# Altium schematic sheet PixelClockController.SchDoc
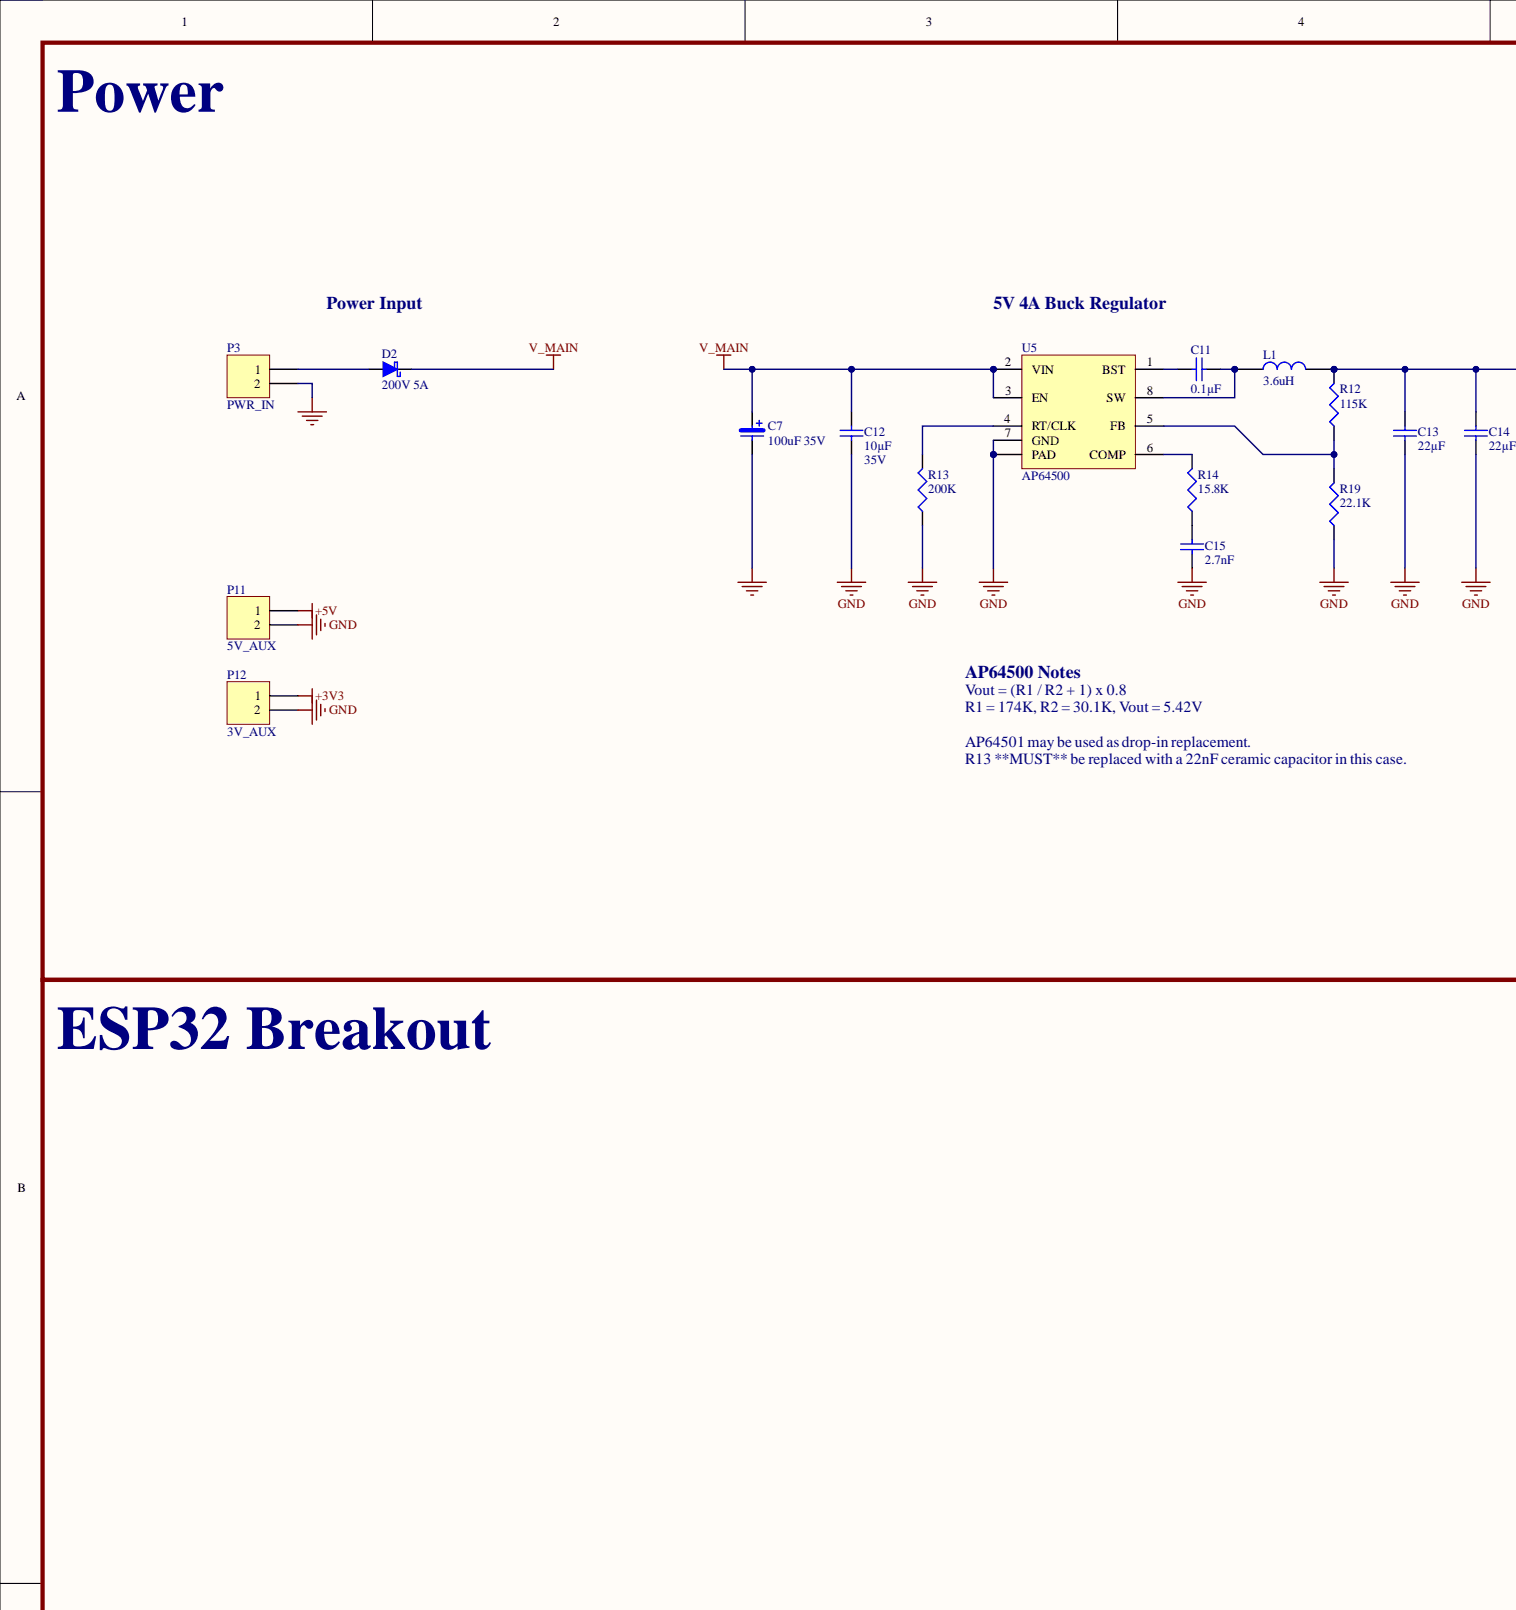
[diagram p0: PixelClockController.SchDoc, top left]
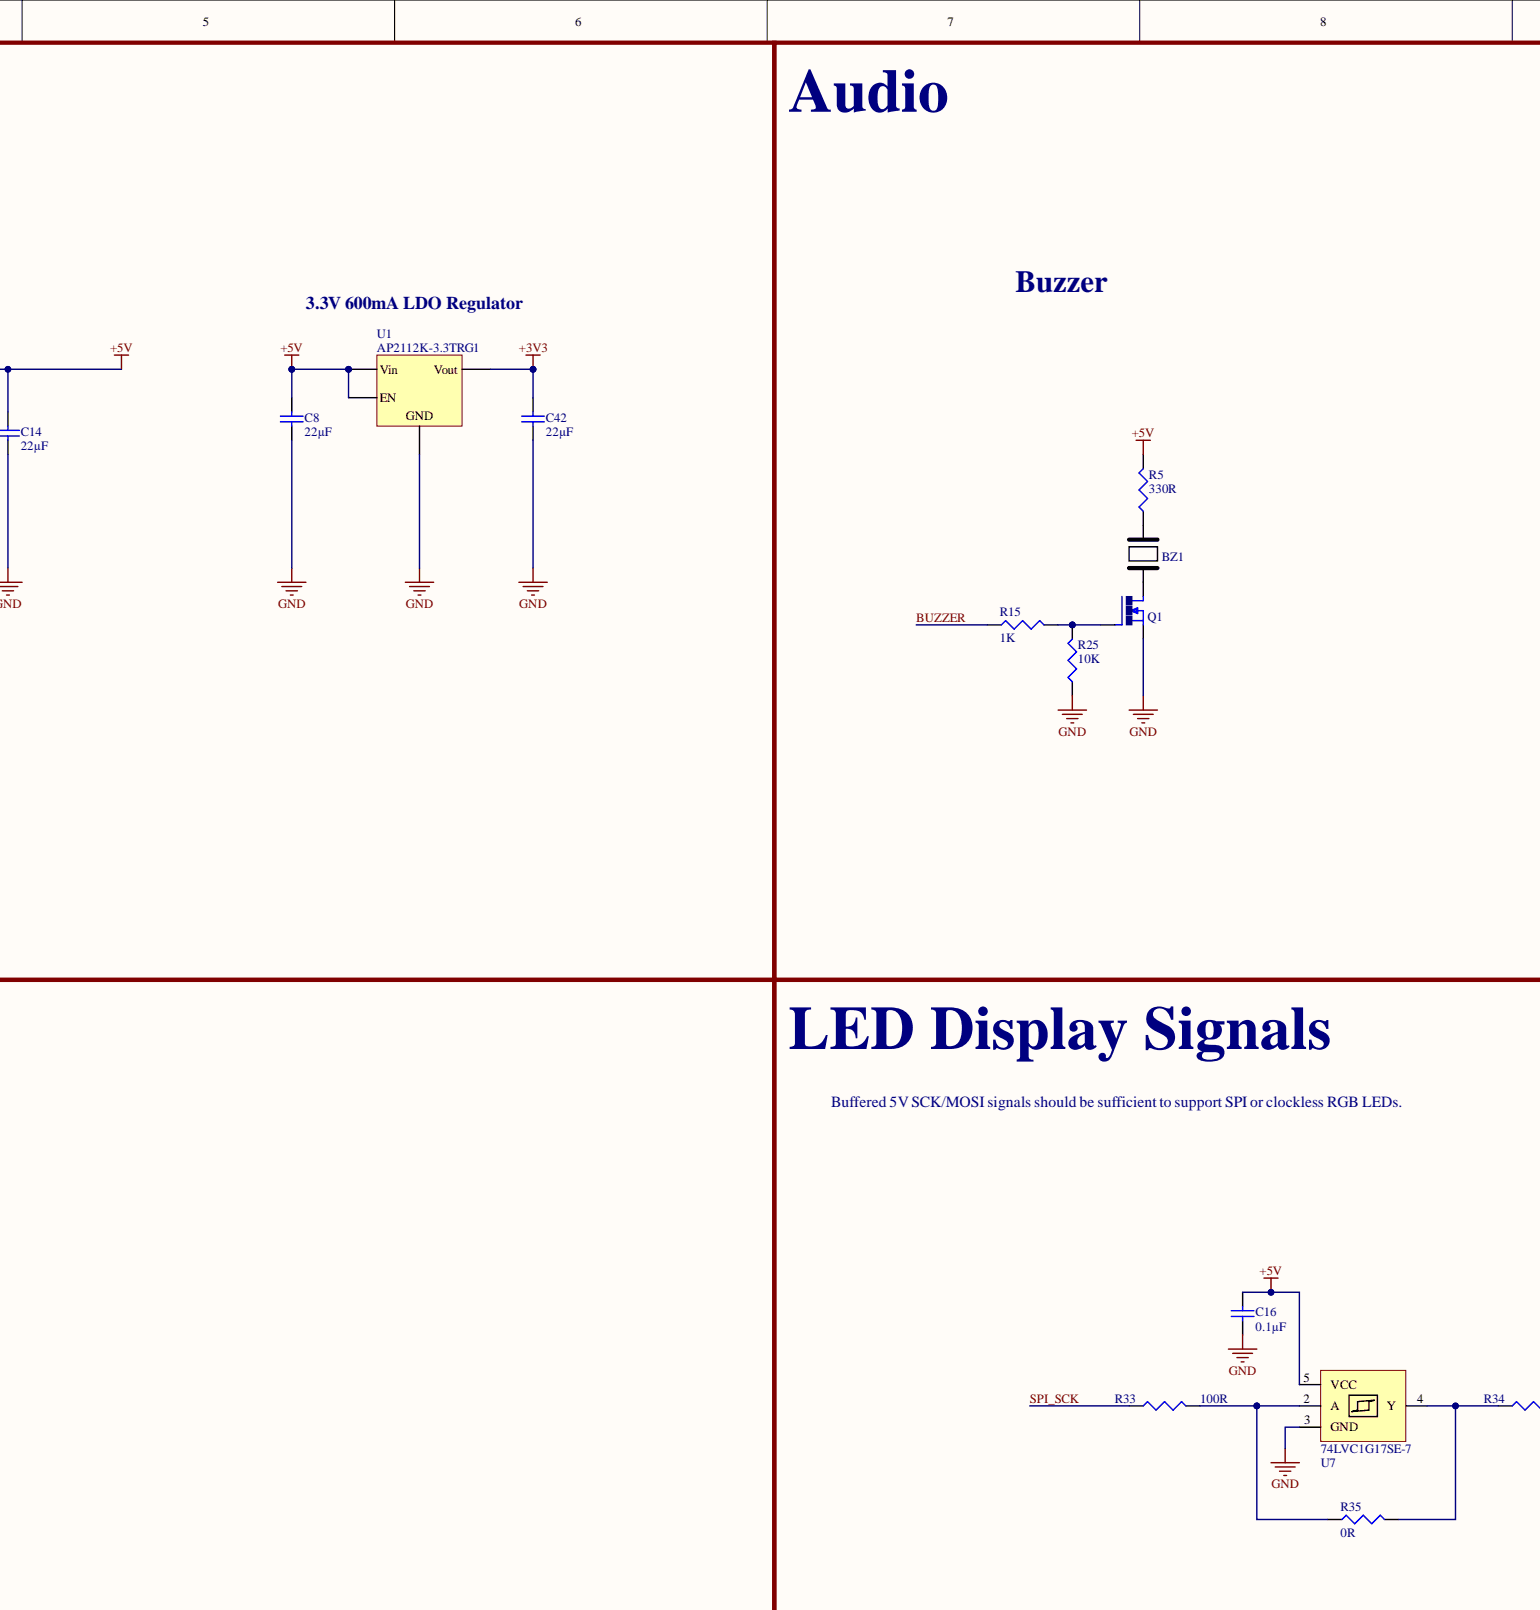
[diagram p1: PixelClockController.SchDoc, top centre]
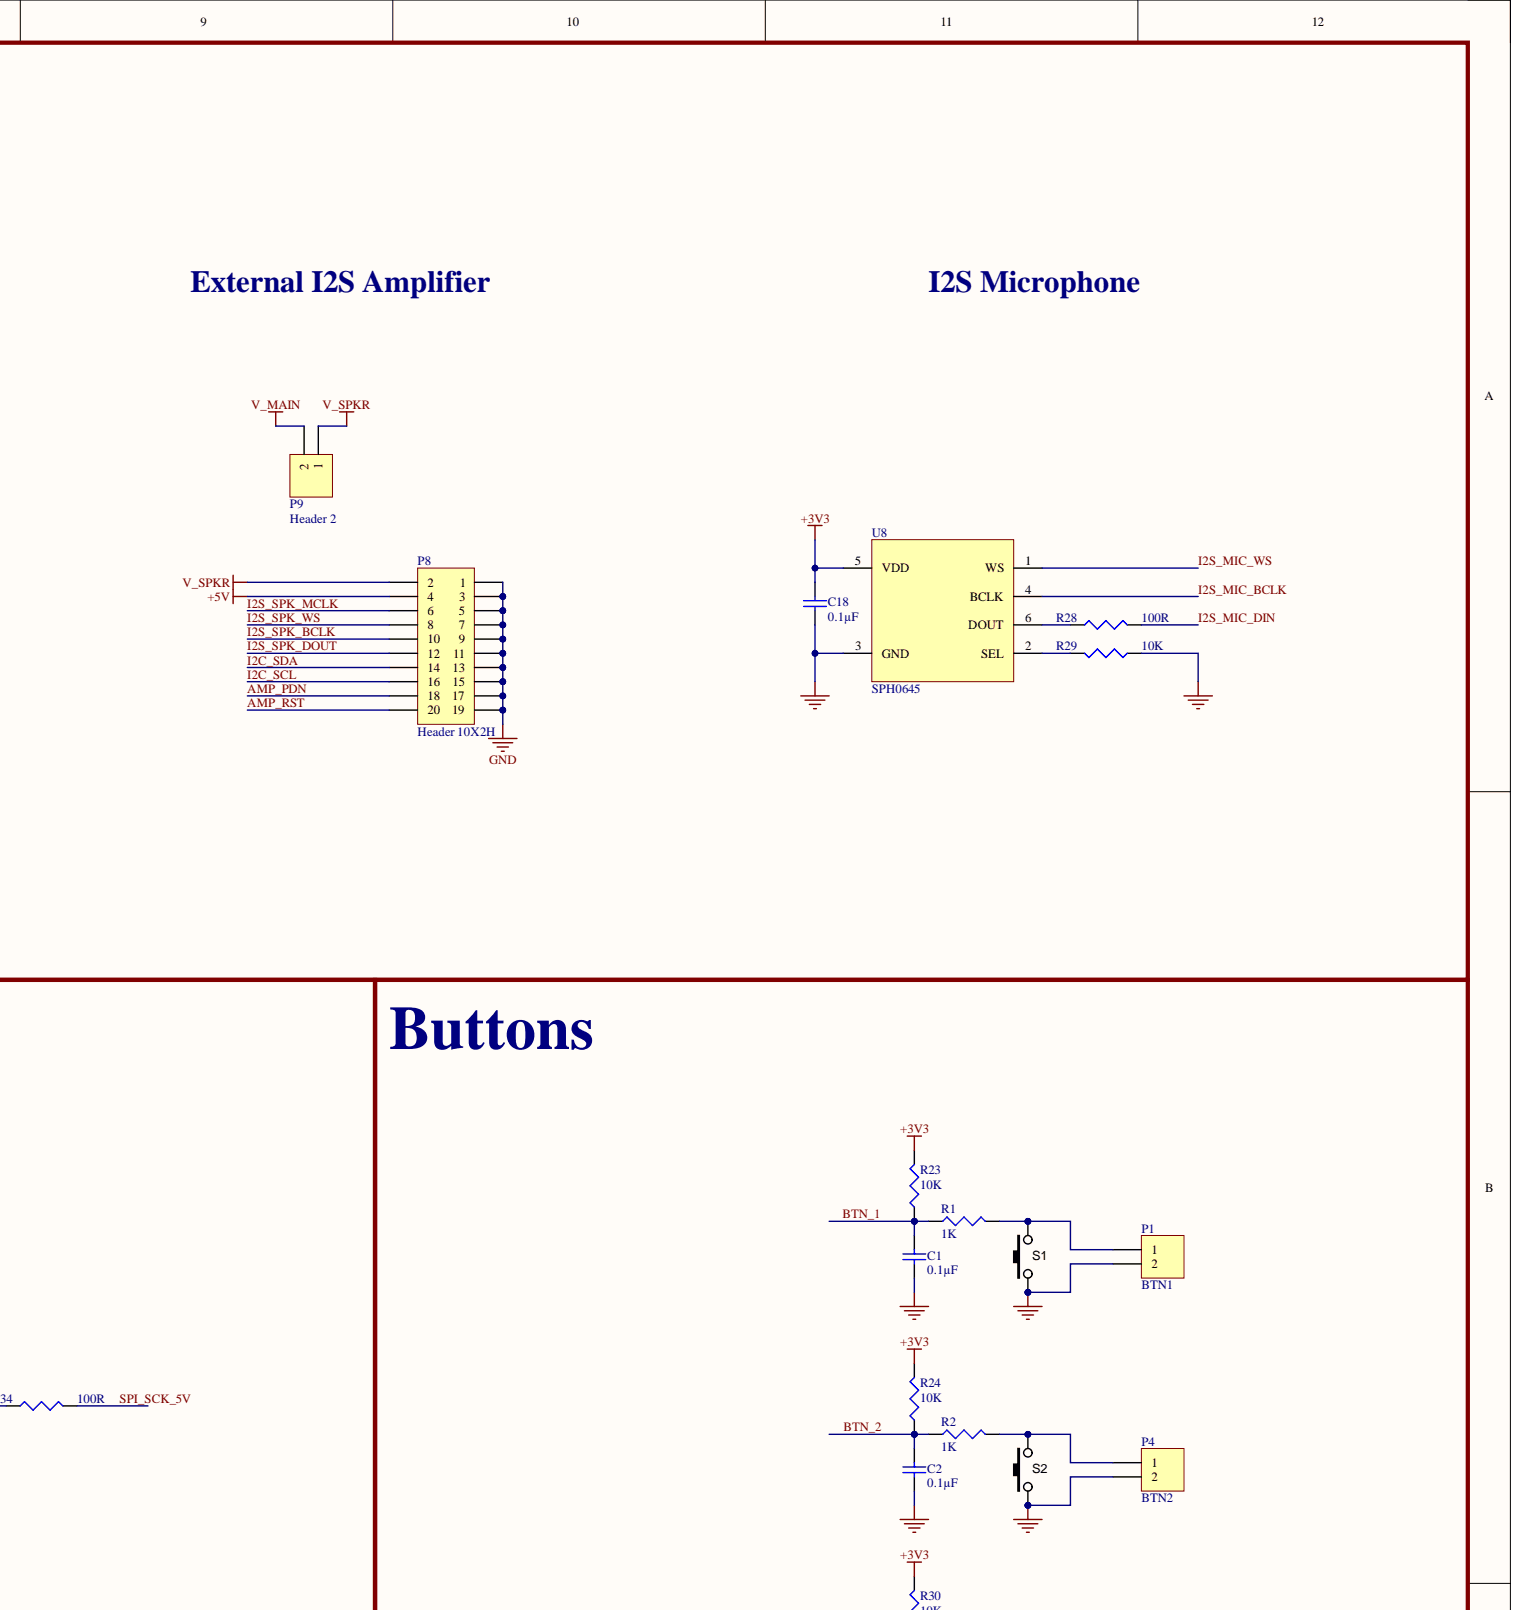
[diagram p2: PixelClockController.SchDoc, top right]
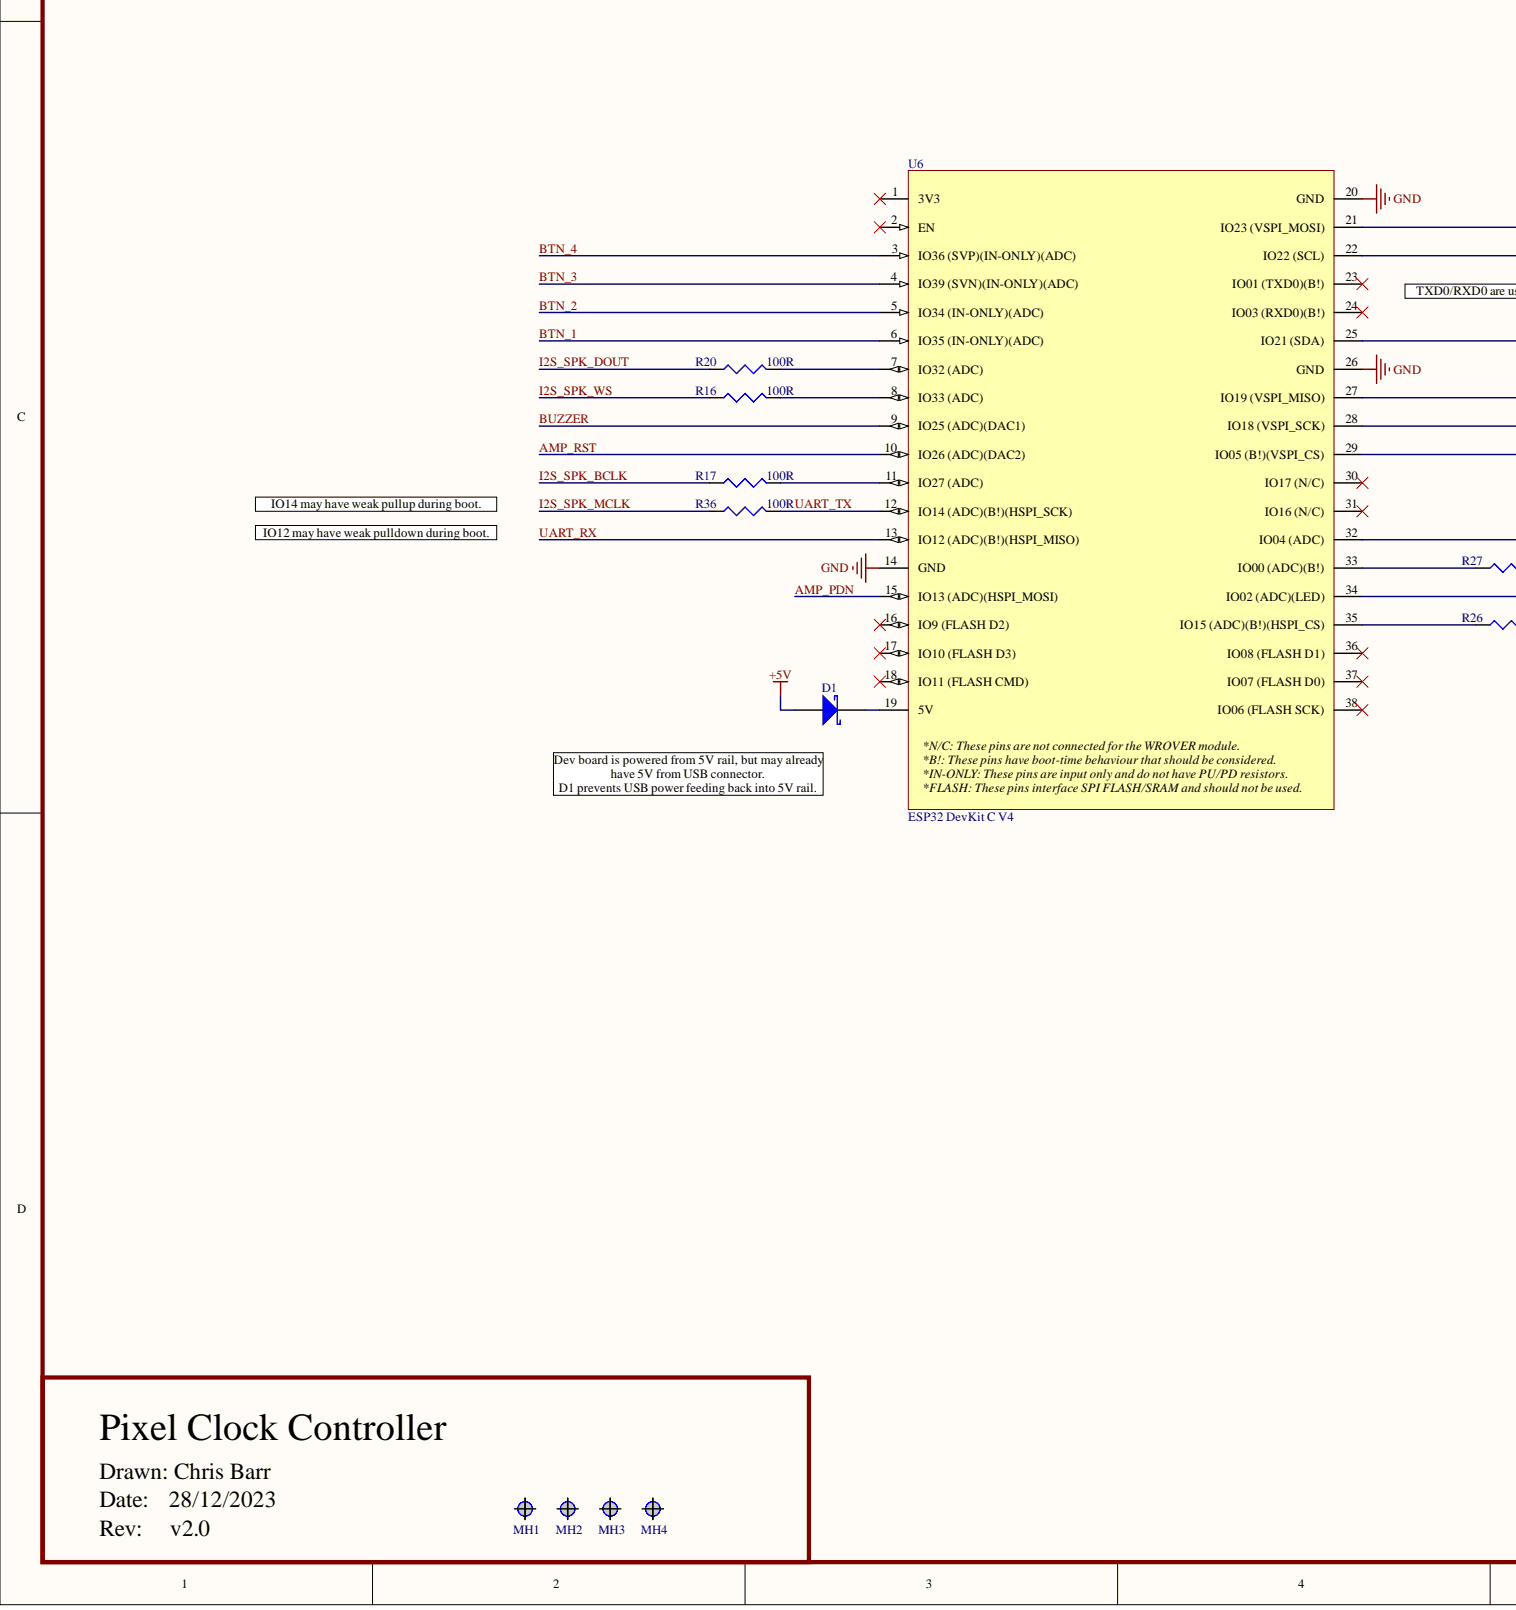
[diagram p3: PixelClockController.SchDoc, bottom left]
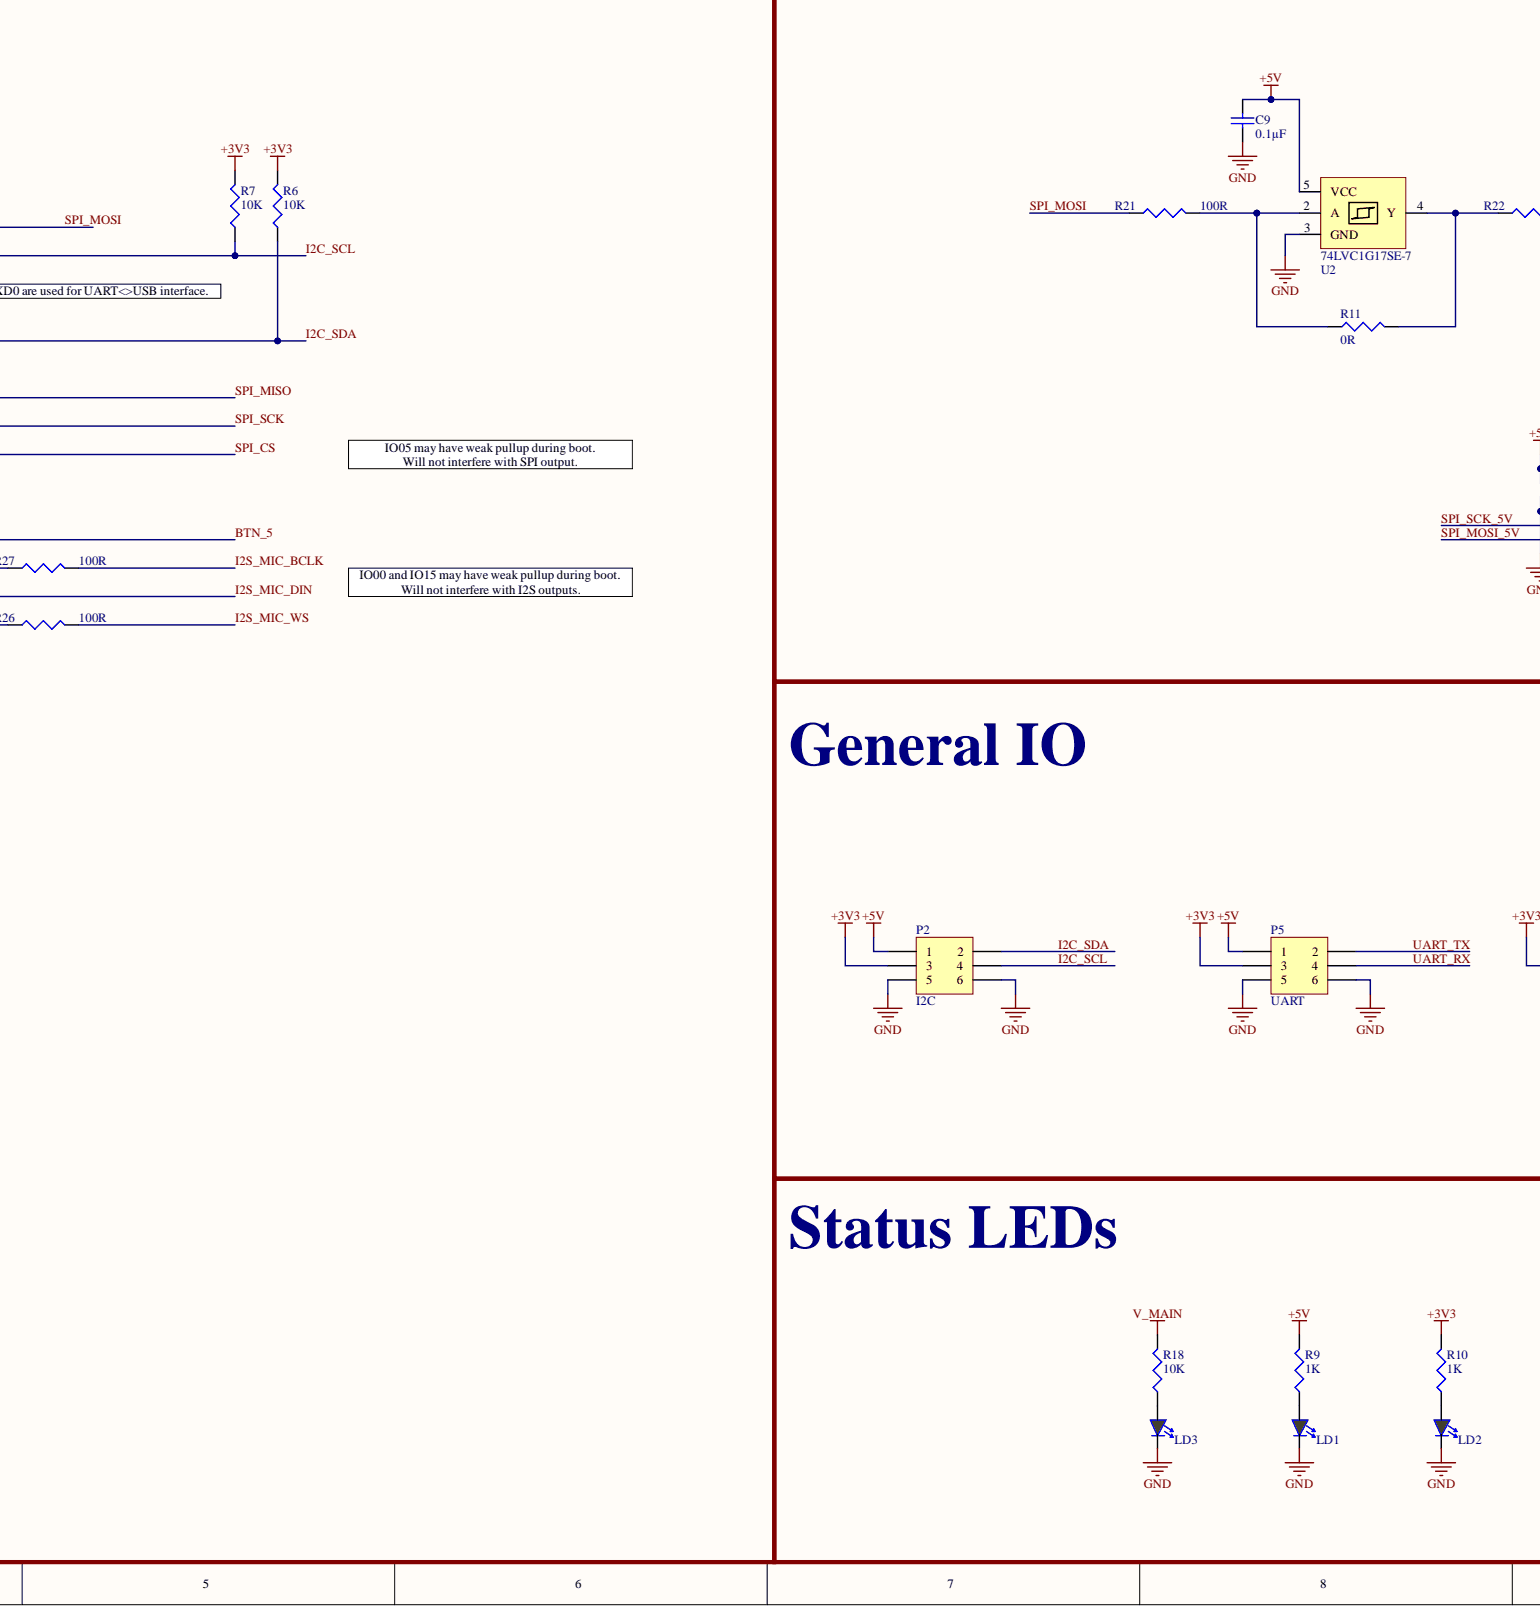
[diagram p4: PixelClockController.SchDoc, bottom centre]
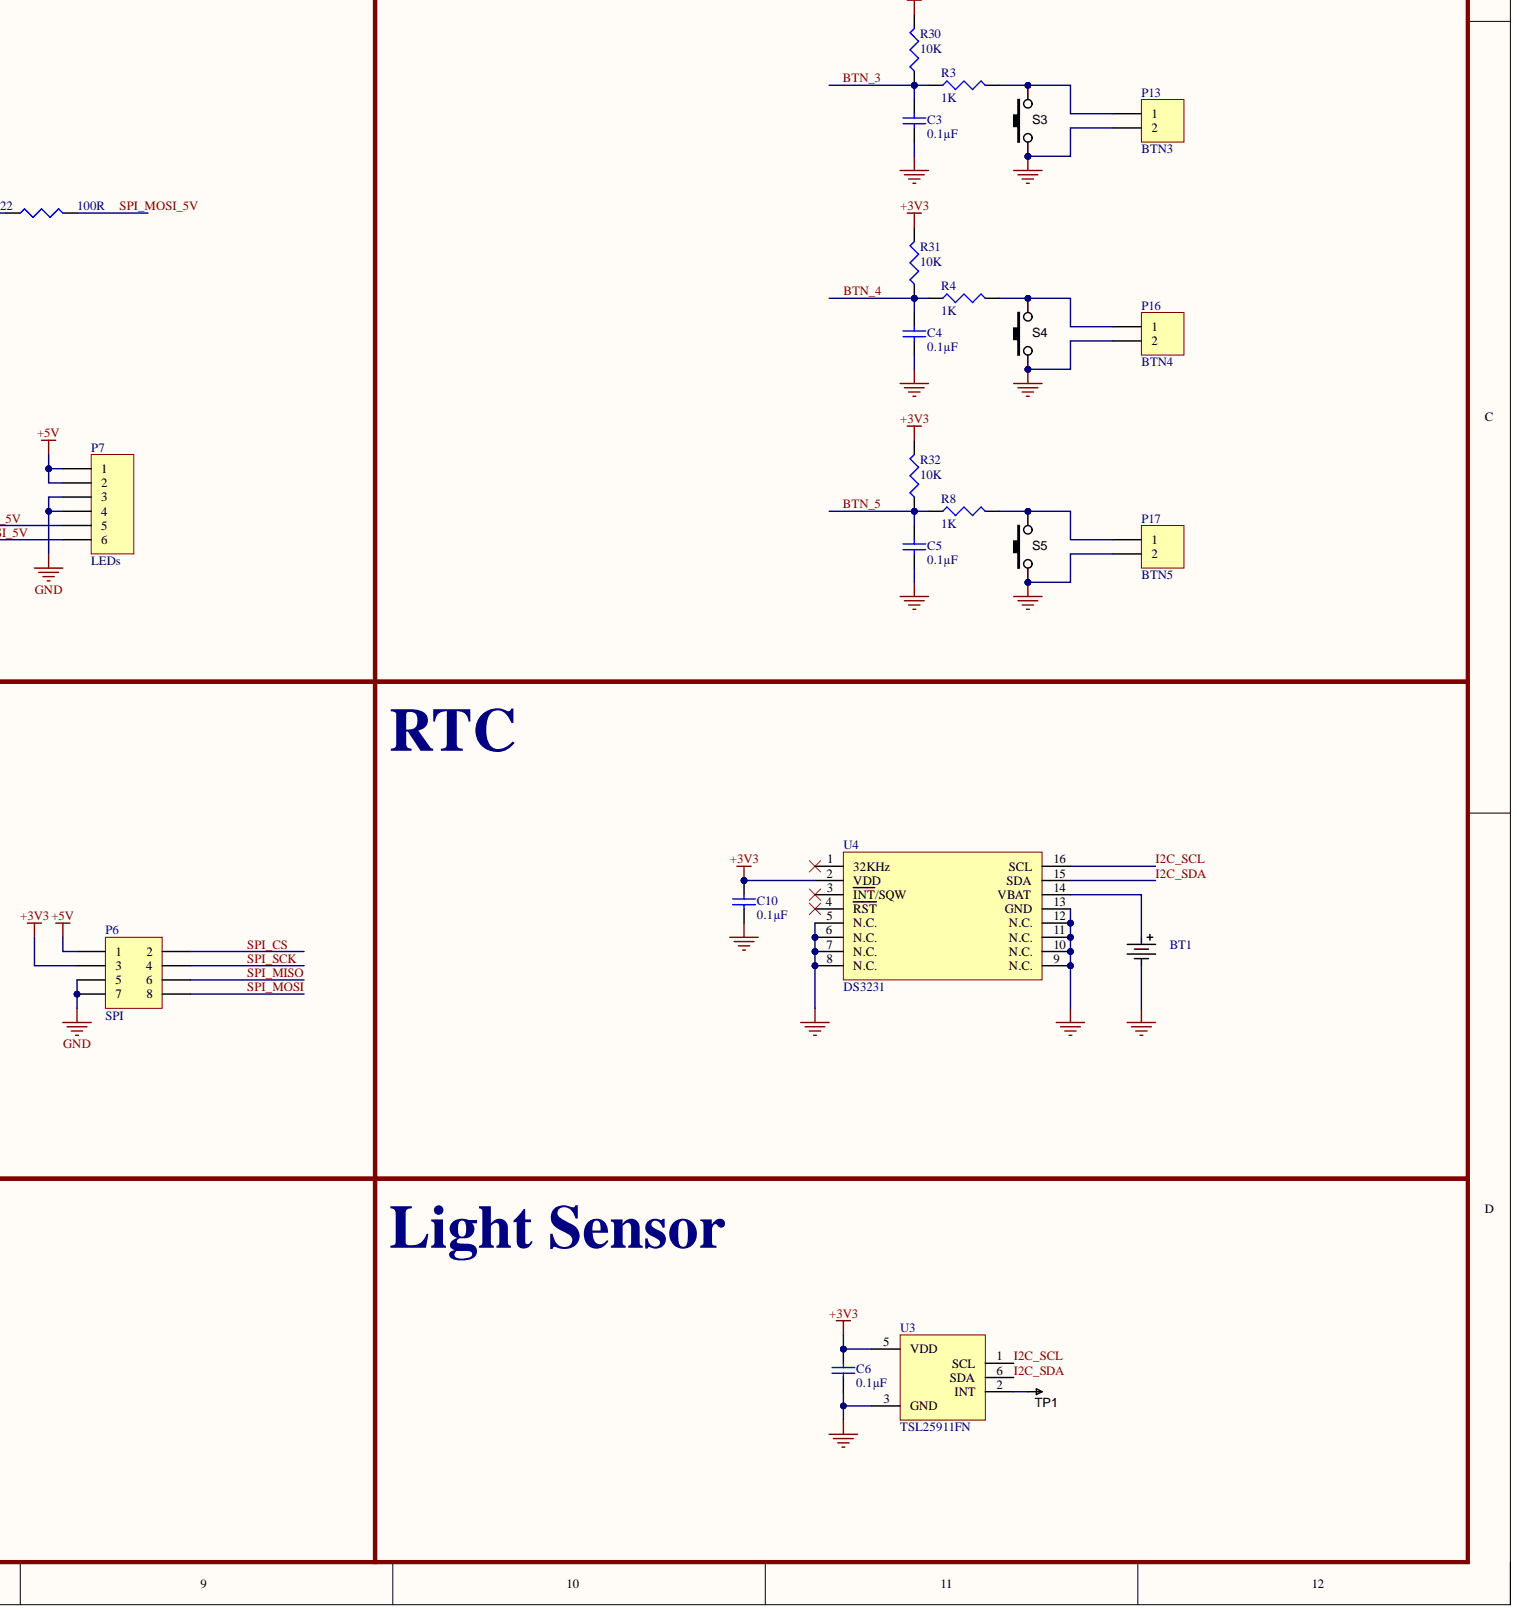
[diagram p5: PixelClockController.SchDoc, bottom right]

## Parts
BT1  Battery - CR16XX Retainer  [Battery - Coin CR16XX TH Clip (BK-869)]  (value not drawn)
BZ1  Piezo Transducer  [PKMCS0909E4000-R1]  (value not drawn)
C1  value 0.1µF  [CAPC1608X90N]  (hidden comment: Capacitor)
C2  value 0.1µF  [CAPC1608X90N]  (hidden comment: Capacitor)
C3  value 0.1µF  [CAPC1608X90N]  (hidden comment: Capacitor)
C4  value 0.1µF  [CAPC1608X90N]  (hidden comment: Capacitor)
C5  value 0.1µF  [CAPC1608X90N]  (hidden comment: Capacitor)
C6  value 0.1µF  [CAPC1608X90N]  (hidden comment: Capacitor)
C7  value 100uF 35V  [CAP EL PANASONIC]  (hidden comment: CapE-6.3-100uF-35V)
C8  value 22µF  [CAPC3216X100N]  (hidden comment: Capacitor)
C9  value 0.1µF  [CAPC1608X90N]  (hidden comment: Capacitor)
C10  value 0.1µF  [CAPC1608X90N]  (hidden comment: Capacitor)
C11  value 0.1µF  [CAPC1608X90N]  (hidden comment: Capacitor)
C12  value 10µF  Voltage: 35V  [CAPC3225X220N]  (hidden comment: Capacitor)
C13  value 22µF  [CAPC3216X100N]  (hidden comment: Capacitor)
C14  value 22µF  [CAPC3216X100N]  (hidden comment: Capacitor)
C15  value 2.7nF  [CAPC1608X90N]  (hidden comment: Capacitor)
C16  value 0.1µF  [CAPC1608X90N]  (hidden comment: Capacitor)
C18  value 0.1µF  [CAPC1608X90N]  (hidden comment: Capacitor)
C42  value 22µF  [CAPC3216X100N]  (hidden comment: Capacitor)
D1  (no value)  [DIOM2616X08N]
D2  value 200V 5A  [DO-214AA]  (hidden comment: Diode SK520BTR)
L1  value 3.6uH  [INDUCTOR_NR8040]  (hidden comment: Inductor SMD 3.6uH 4.9A)
LD1  LEDG  0603  [LED 1608-0603]  (value not drawn)
LD2  LEDG  0603  [LED 1608-0603]  (value not drawn)
LD3  LEDG  0603  [LED 1608-0603]  (value not drawn)
MH1  Screw Hole  [M3-Hole-2]  (value not drawn)  (no BOM)
MH2  Screw Hole  [M3-Hole-2]  (value not drawn)  (no BOM)
MH3  Screw Hole  [M3-Hole-2]  (value not drawn)  (no BOM)
MH4  Screw Hole  [M3-Hole-2]  (value not drawn)  (no BOM)
P1  BTN1  [HDR1X2-HEADER]  (no BOM)
P2  I2C  [HDR2X3-Header]  (no BOM)
P3  PWR_IN  [HDR1X2-HEADER]  (no BOM)
P4  BTN2  [HDR1X2-HEADER]  (no BOM)
P5  UART  [HDR2X3-Header]  (no BOM)
P6  SPI  [HDR2X4-HEADER]  (no BOM)
P7  LEDs  [HDR1X6-HEADER]
P8  Header 10X2H  [HDR2X10H]
P9  Header 2  [HDR1X2-HEADER]
P11  5V_AUX  [HDR1X2-HEADER]  (no BOM)
P12  3V_AUX  [HDR1X2-HEADER]  (no BOM)
P13  BTN3  [HDR1X2-HEADER]  (no BOM)
P16  BTN4  [HDR1X2-HEADER]  (no BOM)
P17  BTN5  [HDR1X2-HEADER]  (no BOM)
Q1  AO3400A  [SOT23-3 New]  (value not drawn)
R1  value 1K  [RES 1608-0603]  (hidden comment: Resistor)
R2  value 1K  [RES 1608-0603]  (hidden comment: Resistor)
R3  value 1K  [RES 1608-0603]  (hidden comment: Resistor)
R4  value 1K  [RES 1608-0603]  (hidden comment: Resistor)
R5  value 330R  [RES 1608-0603]  (hidden comment: Resistor)
R6  value 10K  [RES 1608-0603]  (hidden comment: Resistor)
R7  value 10K  [RES 1608-0603]  (hidden comment: Resistor)
R8  value 1K  [RES 1608-0603]  (hidden comment: Resistor)
R9  value 1K  [RES 1608-0603]  (hidden comment: Resistor)
R10  value 1K  [RES 1608-0603]  (hidden comment: Resistor)
R11  value 0R  [RES 1608-0603]  (hidden comment: Res-0603-0R)
R12  value 115K  [RES 1608-0603]  (hidden comment: Resistor)
R13  value 200K  [RES 1608-0603]  (hidden comment: Resistor)
R14  value 15.8K  [RES 1608-0603]  (hidden comment: Resistor)
R15  value 1K  [RES 1608-0603]  (hidden comment: Resistor)
R16  value 100R  [RES 1608-0603]  (hidden comment: Resistor)
R17  value 100R  [RES 1608-0603]  (hidden comment: Resistor)
R18  value 10K  [RES 1608-0603]  (hidden comment: Resistor)
R19  value 22.1K  [RES 1608-0603]  (hidden comment: Resistor)
R20  value 100R  [RES 1608-0603]  (hidden comment: Resistor)
R21  value 100R  [RES 1608-0603]  (hidden comment: Resistor)
R22  value 100R  [RES 1608-0603]  (hidden comment: Resistor)
R23  value 10K  [RES 1608-0603]  (hidden comment: Resistor)
R24  value 10K  [RES 1608-0603]  (hidden comment: Resistor)
R25  value 10K  [RES 1608-0603]  (hidden comment: Resistor)
R26  value 100R  [RES 1608-0603]  (hidden comment: Resistor)
R27  value 100R  [RES 1608-0603]  (hidden comment: Resistor)
R28  value 100R  [RES 1608-0603]  (hidden comment: Resistor)
R29  value 10K  [RES 1608-0603]  (hidden comment: Resistor)
R30  value 10K  [RES 1608-0603]  (hidden comment: Resistor)
R31  value 10K  [RES 1608-0603]  (hidden comment: Resistor)
R32  value 10K  [RES 1608-0603]  (hidden comment: Resistor)
R33  value 100R  [RES 1608-0603]  (hidden comment: Resistor)
R34  value 100R  [RES 1608-0603]  (hidden comment: Resistor)
R35  value 0R  [RES 1608-0603]  (hidden comment: Res-0603-0R)
R36  value 100R  [RES 1608-0603]  (hidden comment: Resistor)
S1  SW_THT_PB_4.3mm  [TACT-SWITCH-TS1143]  (value not drawn)
S2  SW_THT_PB_4.3mm  [TACT-SWITCH-TS1143]  (value not drawn)
S3  SW_THT_PB_4.3mm  [TACT-SWITCH-TS1143]  (value not drawn)
S4  SW_THT_PB_4.3mm  [TACT-SWITCH-TS1143]  (value not drawn)
S5  SW_THT_PB_4.3mm  [TACT-SWITCH-TS1143]  (value not drawn)
TP1  TESTPOINT  [TP-R60]  (value not drawn)  (no BOM)
U1  AP2112K-3.3TRG1  [SOT23-5]
U2  74LVC1G17SE-7  [SOT353]
U3  TSL25911FN  [SON65P200X70-6N]
U4  DS3231  [SOIC127P1030X265-16M]
U5  AP64500  [SOIC127P600X140_HS-9N]
U6  ESP32 DevKit C V4  [ESP32-WROOM-32 DEVKIT C]
U7  74LVC1G17SE-7  [SOT353]
U8  SPH0645  [SPH0645LM4H-B]

## Nets
+3V3: C6.2 C10.2 C18.2 C42.2 P2.3 P5.3 P6.3 P12.1 R6.2 R7.2 R10.2 R23.2 R24.2 R30.2 R31.2 R32.2 U1.5(Vout) U3.5(VDD) U4.2(VDD) U8.5(VDD)
+5V: C8.2 C9.2 C13.2 C14.2 C16.2 D1.A L1.2 P2.1 P5.1 P6.1 P7.1 P7.2 P8.4 P11.1 R5.2 R9.2 R12.2 U1.1(Vin) U1.3(EN) U2.5(VCC) U7.5(VCC)
GND: BT1.- C1.1 C2.1 C3.1 C4.1 C5.1 C6.1 C7.2 C8.1 C9.1 C10.1 C12.1 C13.1 C14.1 C15.1 C16.1 C18.1 C42.1 LD1.2 LD2.2 LD3.2 P1.2 P2.5 P2.6 P3.2 P4.2 P5.5 P5.6 P6.5 P6.7 P7.3 P7.4 P8.1 P8.3 P8.5 P8.7 P8.9 P8.11 P8.13 P8.15 P8.17 P8.19 P11.2 P12.2 P13.2 P16.2 P17.2 Q1.2 R13.1 R19.1 R25.1 R29.1 S1.2 S2.2 S3.2 S4.2 S5.2 U1.2 U2.3(GND) U3.3(GND) U4.5(N.C.) U4.6(N.C.) U4.7(N.C.) U4.8(N.C.) U4.9(N.C.) U4.10(N.C.) U4.11(N.C.) U4.12(N.C.) U4.13(GND) U5.7(GND) U5.9(PAD) U6.14(GND) U6.20(GND) U6.26(GND) U7.3(GND) U8.3(GND)
V_MAIN: C7.1 C12.2 D2.K P9.2 R18.2 U5.2(VIN) U5.3(EN)
V_SPKR: P8.2 P9.1
AMP_PDN: P8.18 U6.15(IO13_(ADC)(HSPI_MOSI))
AMP_RST: P8.20 U6.10(IO26_(ADC)(DAC2))
BTN_1: C1.2 R1.2 R23.1 U6.6(IO35_(IN-ONLY)(ADC))
BTN_2: C2.2 R2.2 R24.1 U6.5(IO34_(IN-ONLY)(ADC))
BTN_3: C3.2 R3.2 R30.1 U6.4(IO39_(SVN)(IN-ONLY)(ADC))
BTN_4: C4.2 R4.2 R31.1 U6.3(IO36_(SVP)(IN-ONLY)(ADC))
BTN_5: C5.2 R8.2 R32.1 U6.32(IO04_(ADC))
BUZZER: R15.1 U6.9(IO25_(ADC)(DAC1))
I2C_SCL: P2.4 P8.16 R7.1 U3.1(SCL) U4.16(SCL) U6.22(IO22_(SCL))
I2C_SDA: P2.2 P8.14 R6.1 U3.6(SDA) U4.15(SDA) U6.25(IO21_(SDA))
I2S_MIC_BCLK: R27.2 U8.4(BCLK)
I2S_MIC_DIN: R28.2 U6.34(IO02_(ADC)(LED))
I2S_MIC_WS: R26.2 U8.1(WS)
I2S_SPK_BCLK: P8.10 R17.2
I2S_SPK_DOUT: P8.12 R20.2
I2S_SPK_MCLK: P8.6 R36.2
I2S_SPK_WS: P8.8 R16.2
SPI_CS: P6.2 U6.29(IO05_(B!)(VSPI_CS))
SPI_MISO: P6.6 U6.27(IO19_(VSPI_MISO))
SPI_MOSI: P6.8 R21.1 U6.21(IO23_(VSPI_MOSI))
SPI_MOSI_5V: P7.6 R22.2
SPI_SCK: P6.4 R33.1 U6.28(IO18_(VSPI_SCK))
SPI_SCK_5V: P7.5 R34.2
UART_RX: P5.4 U6.13(IO12_(ADC)(B!)(HSPI_MISO))
UART_TX: P5.2 R36.1 U6.12(IO14_(ADC)(B!)(HSPI_SCK))
NetBT1_+: BT1.+ U4.14(VBAT)
NetBZ1_1: BZ1.1 R5.1
NetBZ1_2: BZ1.2 Q1.3
NetC11_1: C11.1 L1.1 U5.8(SW)
NetC11_2: C11.2 U5.1(BST)
NetC15_2: C15.2 R14.1
NetD1_K: D1.K U6.19(5V)
NetD2_A: D2.A P3.1
NetLD1_1: LD1.1 R9.1
NetLD2_1: LD2.1 R10.1
NetLD3_1: LD3.1 R18.1
NetP1_1: P1.1 R1.1 S1.1
NetP4_1: P4.1 R2.1 S2.1
NetP13_1: P13.1 R3.1 S3.1
NetP16_1: P16.1 R4.1 S4.1
NetP17_1: P17.1 R8.1 S5.1
NetQ1_1: Q1.1 R15.2 R25.2
NetR11_1: R11.1 R21.2 U2.2(A)
NetR11_2: R11.2 R22.1 U2.4(Y)
NetR12_1: R12.1 R19.2 U5.5(FB)
NetR13_2: R13.2 U5.4(RT/CLK)
NetR14_2: R14.2 U5.6(COMP)
NetR16_1: R16.1 U6.8(IO33_(ADC))
NetR17_1: R17.1 U6.11(IO27_(ADC))
NetR20_1: R20.1 U6.7(IO32_(ADC))
NetR26_1: R26.1 U6.35(IO15_(ADC)(B!)(HSPI_CS))
NetR27_1: R27.1 U6.33(IO00_(ADC)(B!))
NetR28_1: R28.1 U8.6(DOUT)
NetR29_2: R29.2 U8.2(SEL)
NetR33_2: R33.2 R35.1 U7.2(A)
NetR34_1: R34.1 R35.2 U7.4(Y)
NetTP1_1: TP1.1 U3.2(INT)

Not connected: MH1 M3; MH2 M3; MH3 M3; MH4 M3; U4 1(32KHz) 3(INT/SQW) 4(RST); U6 1(3V3) 2(EN) 16(IO9_(FLASH_D2)) 17(IO10_(FLASH_D3)) 18(IO11_(FLASH_CMD)) 23(IO01_(TXD0)(B!)) 24(IO03_(RXD0)(B!)) 30(IO17_(N/C)) 31(IO16_(N/C)) 36(IO08_(FLASH_D1)) 37(IO07_(FLASH_D0)) 38(IO06_(FLASH_SCK))
